# Altium schematic sheet Arduboy_V3.5-2.SchDoc(Arduboy_V3.5-2)
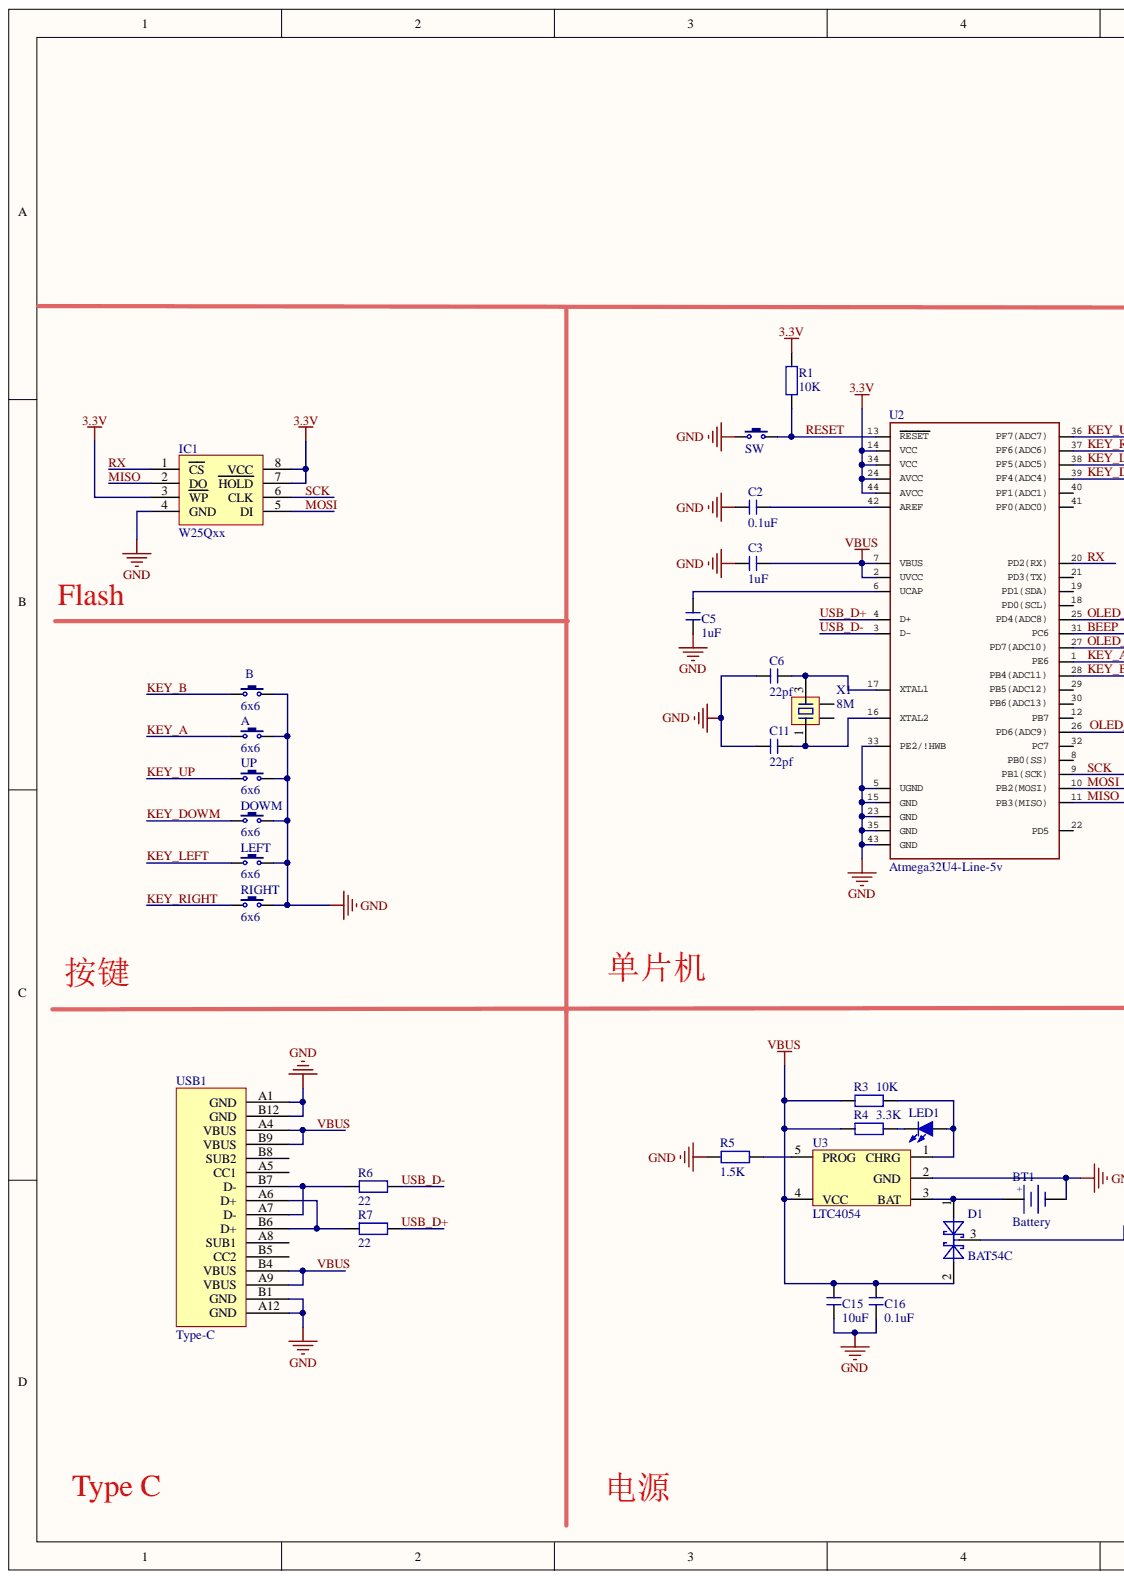
[diagram p0: Arduboy_V3.5-2.SchDoc, left]
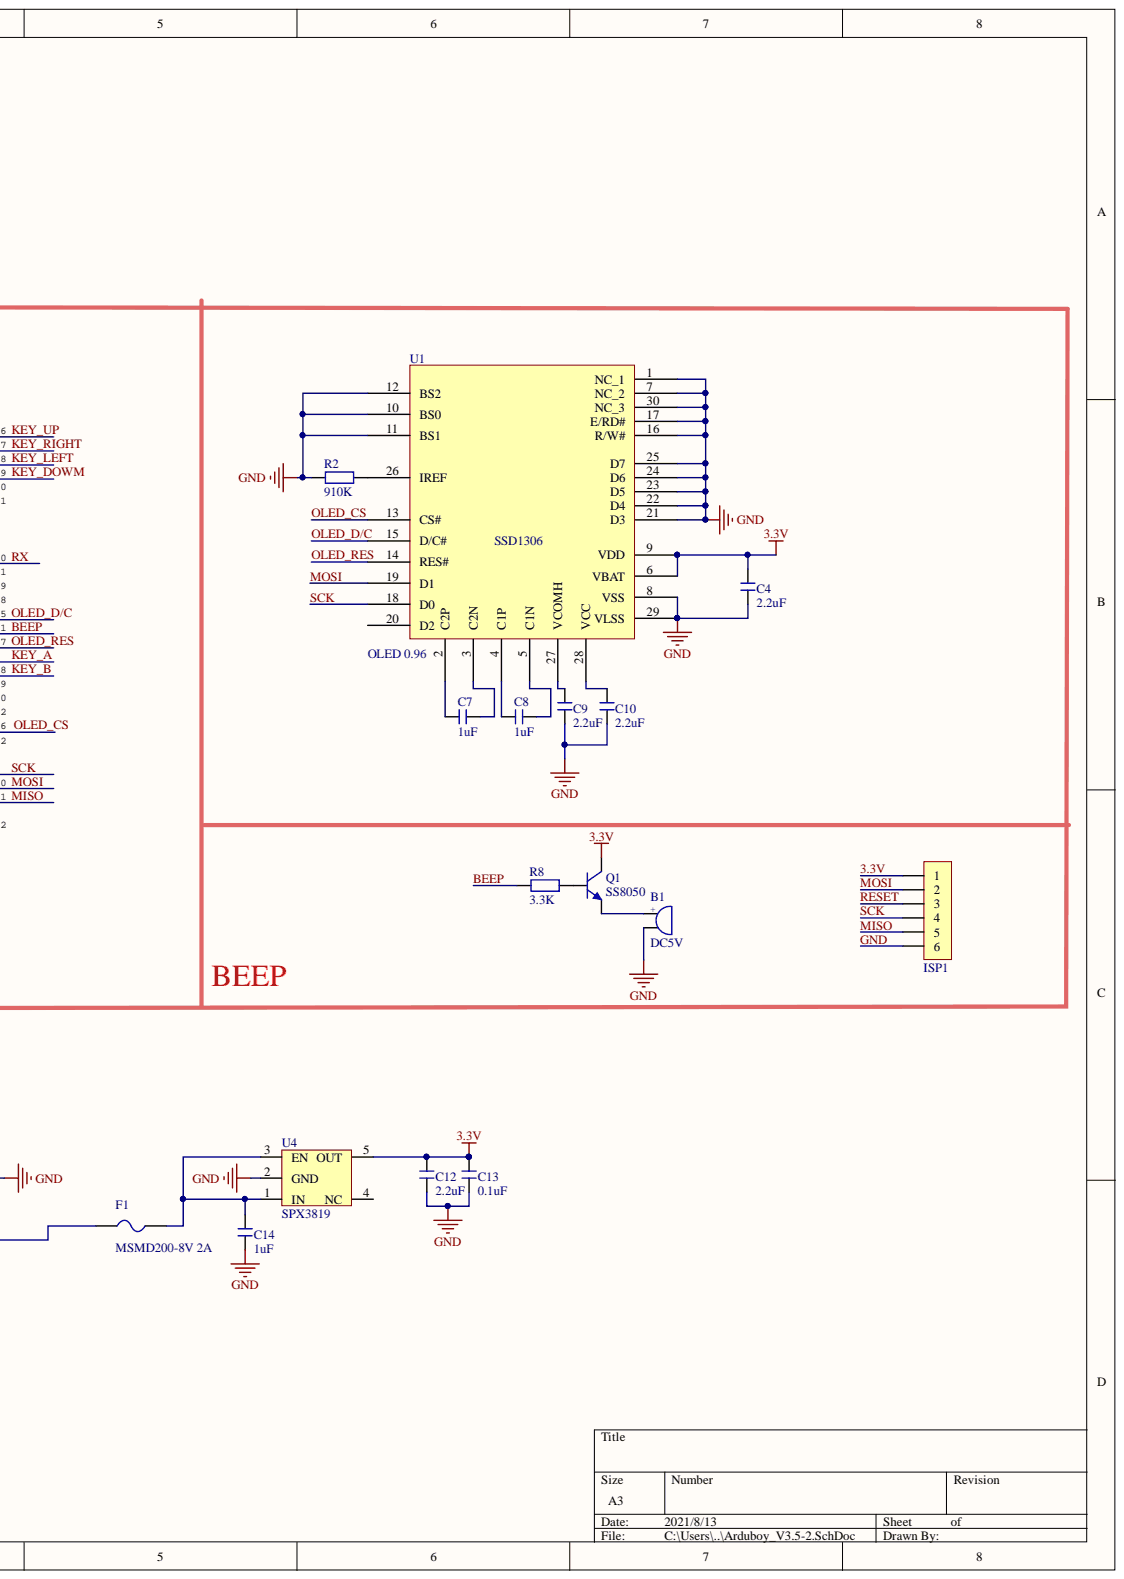
[diagram p1: Arduboy_V3.5-2.SchDoc, right]

## Parts
A  6x6  [SW SMD-4.8*4.8*0.5]
B  6x6  [SW SMD-4.8*4.8*0.5]
B1  DC5V  [BEEP SMD-2]
BT1  Battery  [Li-Bettery SMD]
C2  0.1uF  [C 0603]
C3  1uF  [C 0603]
C4  2.2uF  [C 0603]
C5  1uF  [C 0603]
C6  22pf  [C 0603]
C7  1uF  [C 0603]
C8  1uF  [C 0603]
C9  2.2uF  [C 0603]
C10  2.2uF  [C 0603]
C11  22pf  [C 0603]
C12  2.2uF  [C 0603]
C13  0.1uF  [C 0603]
C14  1uF  [C 0603]
C15  10uF  [C 0603]
C16  0.1uF  [C 0603]
D1  BAT54C  [SOT23-3M]
DOWM  6x6  [SW SMD-4.8*4.8*0.5]
F1  MSMD200-8V 2A  [FU 0603]
IC1  W25Qxx  [SOP8W_N]
ISP1  HDR-1X6  [HDR1.27 1x6-M]  (value not drawn)
LED1  (no value)  [LED 0603B]
LEFT  6x6  [SW SMD-4.8*4.8*0.5]
Q1  SS8050  [SOT23-3M]
R1  10K  [R 0603]
R2  910K  [R 0603]
R3  10K  [R 0603]
R4  3.3K  [R 0603]
R5  1.5K  [R 0603]
R6  22  [R 0603]
R7  22  [R 0603]
R8  3.3K  [R 0603]
RIGHT  6x6  [SW SMD-4.8*4.8*0.5]
SW  3x6x5  [SW SMD-4.8*4.8*0.5]  (value not drawn)
U1  OLED 0.96  [OLED 0.96]
U2  Atmega32U4-Line-5v  [TQFP44 10x10_M]
U3  LTC4054  [SOT23-5M]
U4  SPX3819  [SOT23-5N]
UP  6x6  [SW SMD-4.8*4.8*0.5]
USB1  Type-C  [Type C 16p]
X1  8M  [XTAL-3225]

## Nets
3.3V: C4.2 C12.2 C13.2 IC1.3(WP) IC1.7(HOLD) IC1.8(VCC) ISP1.1 Q1.3 R1.2 U1.6(VBAT) U1.9(VDD) U2.14(VCC) U2.24(AVCC) U2.34(VCC) U2.44(AVCC) U4.5(OUT)
BEEP: R8.1 U2.31(PC6)
GND: A.2 B.2 B1.2 BT1.2 C2.1 C3.1 C4.1 C5.2 C6.1 C9.1 C10.1 C11.1 C12.1 C13.1 C14.1 C15.1 C16.1 DOWM.2 IC1.4(GND) ISP1.6 LEFT.2 R2.1 R5.2 RIGHT.2 SW.1 U1.1(NC_1) U1.7(NC_2) U1.8(VSS) U1.10(BS0) U1.11(BS1) U1.12(BS2) U1.16(R/W#) U1.17(E/RD#) U1.21(D3) U1.22(D4) U1.23(D5) U1.24(D6) U1.25(D7) U1.29(VLSS) U1.30(NC_3) U2.5(UGND) U2.15(GND) U2.23(GND) U2.33(PE2/!HWB) U2.35(GND) U2.43(GND) U3.2(GND) U4.2(GND) UP.2 USB1.A1(GND) USB1.A12(GND) USB1.B1(GND) USB1.B12(GND)
KEY_A: A.1 U2.1(PE6)
KEY_B: B.1 U2.28(PB4(ADC11))
KEY_DOWM: DOWM.1 U2.39(PF4(ADC4))
KEY_LEFT: LEFT.1 U2.38(PF5(ADC5))
KEY_RIGHT: RIGHT.1 U2.37(PF6(ADC6))
KEY_UP: U2.36(PF7(ADC7)) UP.1
MISO: IC1.2(DO) ISP1.5 U2.11(PB3(MISO))
MOSI: IC1.5(DI) ISP1.2 U1.19(D1) U2.10(PB2(MOSI))
OLED_CS: U1.13(CS#) U2.26(PD6(ADC9))
OLED_D/C: U1.15(D/C#) U2.25(PD4(ADC8))
OLED_RES: U1.14(RES#) U2.27(PD7(ADC10))
RESET: ISP1.3 R1.1 SW.2 U2.13(RESET)
RX: IC1.1(CS) U2.20(PD2(RX))
SCK: IC1.6(CLK) ISP1.4 U1.18(D0) U2.9(PB1(SCK))
USB_D+: R7.1 U2.4(D+)
USB_D-: R6.1 U2.3(D-)
VBUS: C3.2 C15.2 C16.2 D1.2 R3.1 R4.2 U2.2(UVCC) U2.7(VBUS) U3.4(VCC) USB1.A4(VBUS) USB1.A9(VBUS) USB1.B4(VBUS) USB1.B9(VBUS)
NetB1_1: B1.1 Q1.2
NetBT1_1: BT1.1 D1.1 U3.3(BAT)
NetC2_2: C2.2 U2.42(AREF)
NetC5_1: C5.1 U2.6(UCAP)
NetC6_2: C6.2 U2.17(XTAL1) X1.3
NetC7_1: C7.1 U1.2(C2P)
NetC7_2: C7.2 U1.3(C2N)
NetC8_1: C8.1 U1.4(C1P)
NetC8_2: C8.2 U1.5(C1N)
NetC9_2: C9.2 U1.27(VCOMH)
NetC10_2: C10.2 U1.28(VCC)
NetC11_2: C11.2 U2.16(XTAL2) X1.1
NetC14_2: C14.2 F1.2 U4.1(IN) U4.3(EN)
NetD1_3: D1.3 F1.1
NetLED1_1: LED1.1 R3.2 U3.1(CHRG)
NetLED1_2: LED1.2 R4.1
NetQ1_1: Q1.1 R8.2
NetR2_2: R2.2 U1.26(IREF)
NetR5_1: R5.1 U3.5(PROG)
NetR6_2: R6.2 USB1.A7(D-) USB1.B7(D-)
NetR7_2: R7.2 USB1.A6(D+) USB1.B6(D+)

Not connected: U1 20(D2); U2 8(PB0(SS)) 12(PB7) 18(PD0(SCL)) 19(PD1(SDA)) 21(PD3(TX)) 22(PD5) 29(PB5(ADC12)) 30(PB6(ADC13)) 32(PC7) 40(PF1(ADC1)) 41(PF0(ADC0)); U4 4(NC); USB1 A5(CC1) A8(SUB1) B5(CC2) B8(SUB2); X1 2 4

## Library data of the parts
A B DOWM LEFT RIGHT UP = SW SMD-2: Arduboy 用; Library Name: 按键-开关.SchLib; Component Kind: Standard
B1 = BEEP: 蜂鸣器; 版权归属: 黎明电子style; 学习资源: https://lmdzstyle.taobao.com/; Library Name: 未分类器件.SchLib; Component Kind: Standard; BOM名称: 蜂鸣器
BT1 = Battery: 锂电池; Library Name: 未分类器件.SchLib; Component Kind: Standard
C2-C16 = C: 无极性贴片电容; 版权归属: 黎明电子style; 学习资源: https://lmdzstyle.taobao.com/; Library Name: 电阻-电容-电感.SchLib; Component Kind: Standard; BOM名称: 无极性贴片电容
D1 = BAT54C: 双肖基特二极管; Library Name: 二极管-整流桥.SchLib; Component Kind: Standard
F1 = FU: 贴片保险丝; 版权归属: 黎明电子style; 学习资源: https://lmdzstyle.taobao.com/; Library Name: 保险丝-晶振.SchLib; Component Kind: Standard; BOM名称: 贴片保险丝
IC1 = W25Qxx: 外置Flash; 版权归属: 黎明电子style; 学习资源: https://lmdzstyle.taobao.com/; Library Name: IC芯片.SchLib; Component Kind: Standard; BOM名称: 外置Flash
ISP1 = Header 6: 6P接插件; 版权归属: 黎明电子style; 学习资源: https://lmdzstyle.taobao.com/; Library Name: 接插件.SchLib; Component Kind: Standard; BOM名称: 6P接插件
LED1 = LED-SMD: 贴片LED; 版权归属: 黎明电子style; 学习资源: https://lmdzstyle.taobao.com/; Library Name: LED.SchLib; Component Kind: Standard; BOM名称: 贴片LED
Q1 = 8050-SMD: 高频放大-NPN型; 版权归属: 黎明电子style; 属性: 40V 1.5A 1W 100MHZ; 学习资源: https://lmdzstyle.taobao.com/; Library Name: 三极管.SchLib; Component Kind: Standard; BOM名称: 高频放大-NPN型
R1-R8 = R: 贴片电阻; 版权归属: 黎明电子style; 学习资源: https://lmdzstyle.taobao.com/; Library Name: 电阻-电容-电感.SchLib; Component Kind: Standard; BOM名称: 贴片电阻
SW = TSW 3x6: 3x6轻触开关; 版权归属: 黎明电子style; 学习资源: https://lmdzstyle.taobao.com/; Library Name: 按键-开关.SchLib; Component Kind: Standard; BOM名称: 3x6轻触开关
U3 = LTC4054: 充电管理; Library Name: IC芯片.SchLib; Component Kind: Standard
U4 = SPX3819: 3.3V LDO 稳压; Library Name: IC芯片.SchLib; Component Kind: Standard
USB1 = Type-C Socket: USB 3.1  单排16PIN四脚插板(L=7.35) 一体式MD; Library Name: 接口类.SchLib; ComponentLink1URL: https://item.szlcsc.com/293008.html; ComponentLink1Description: USB 3.1 C TYPE单排16PIN四脚插板(L=7.35) 一体式MD; Component Kind: Standard
X1 = XTAL-4: 4脚晶振; 版权归属: 黎明电子style; 学习资源: https://lmdzstyle.taobao.com/; Library Name: 保险丝-晶振.SchLib; Component Kind: Standard; BOM名称: 4脚晶振
U1 = OLED 0.96: Library Name: 显示器件.SchLib; Component Kind: Standard
U2 = Atmega32U4-Line-5v: Library Name: Atmel系列单片机.SchLib; Component Kind: Standard
